# Altium schematic sheet PCIE_CONN.SchDoc(PCIE_CONN)
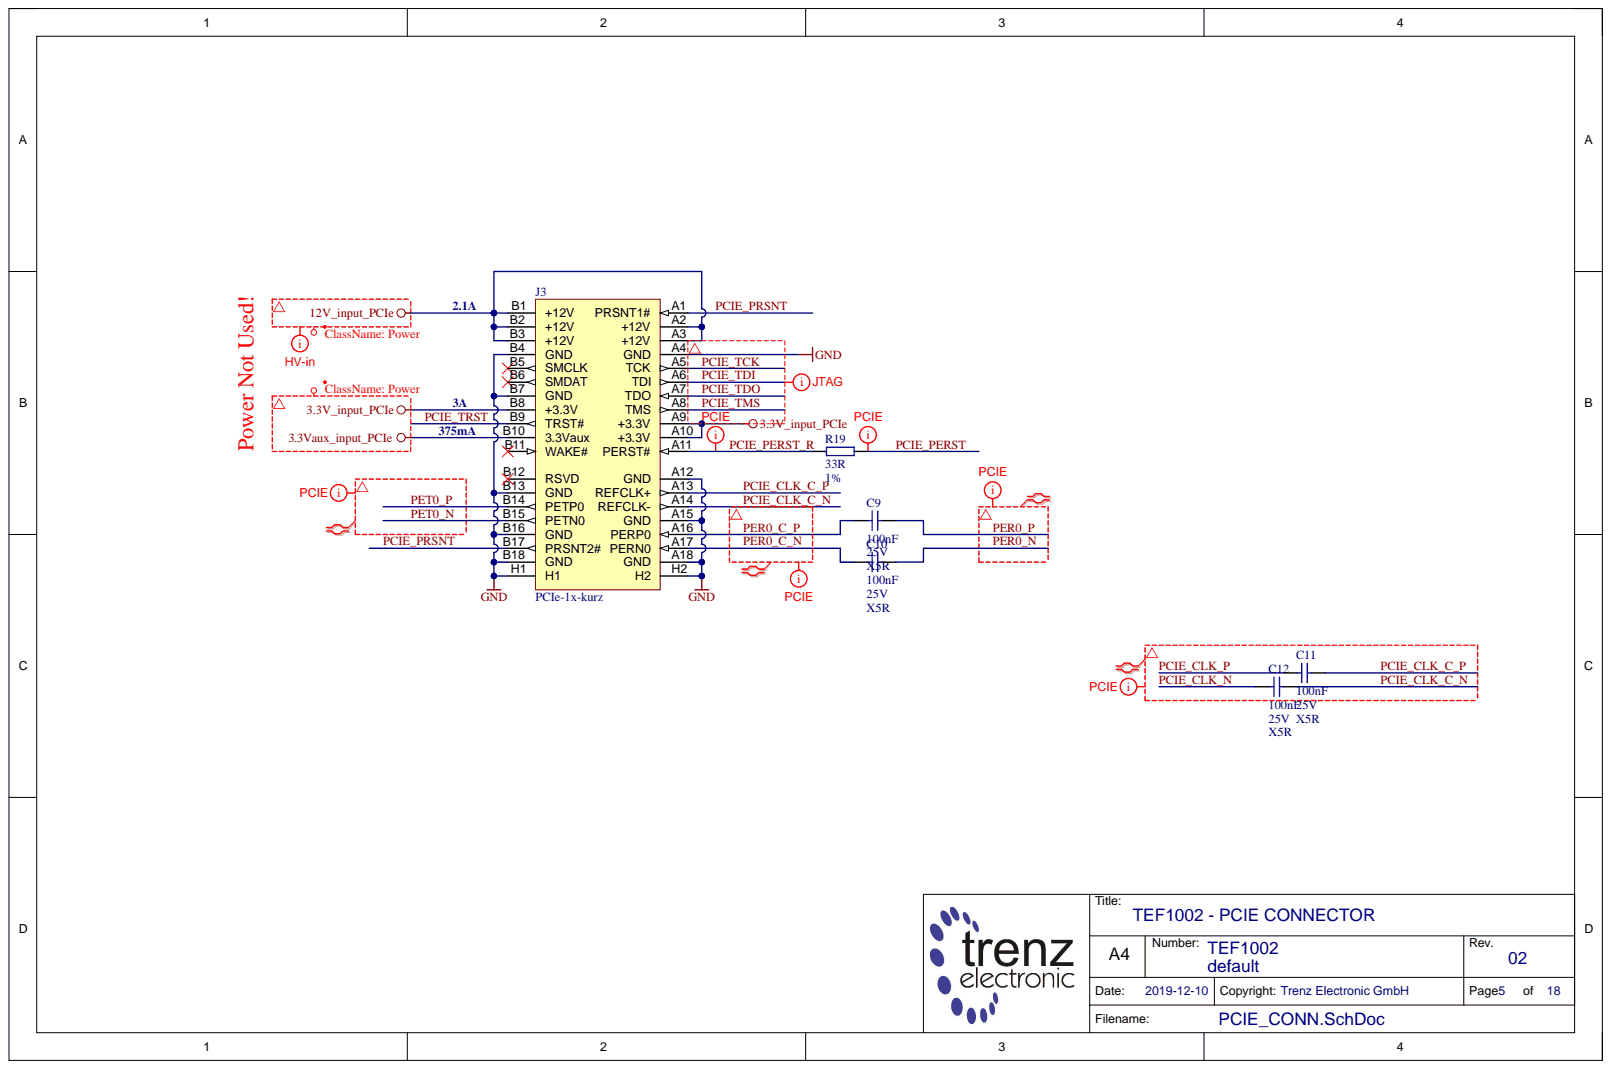
[diagram p0: PCIE_CONN.SchDoc]

## Parts
C9  100nF  [CAPC1005X55N]
C10  100nF  Technology: X5R  Voltage: 25V  [CAPC1005X55N]
C11  100nF  [CAPC1005X55N]
C12  100nF  Technology: X5R  Voltage: 25V  [CAPC1005X55N]
J3  PCIe-1x-kurz  [PCIe-1x-kurz]  (no BOM)
R19  33R  Tolerance: 1%  [RESC0603X26N]

## Nets
3.3V_input_PCIe: J3.A9(+3.3V) J3.A10(+3.3V) J3.B8(+3.3V)
3.3Vaux_input_PCIe: J3.B10(3.3Vaux)
12V_input_PCIe: J3.A2(+12V) J3.A3(+12V) J3.B1(+12V) J3.B2(+12V) J3.B3(+12V)
GND: J3.A4(GND) J3.A12(GND) J3.A15(GND) J3.A18(GND) J3.B4(GND) J3.B7(GND) J3.B13(GND) J3.B16(GND) J3.B18(GND) J3.H1 J3.H2
PCIE_CLK_C_N: C12.2 J3.A14(REFCLK-)
PCIE_CLK_C_P: C11.2 J3.A13(REFCLK+)
PCIE_CLK_N: C12.1
PCIE_CLK_P: C11.1
PCIE_PERST: R19.1
PCIE_PERST_R: J3.A11(PERST#) R19.2
PCIE_PRSNT: J3.A1(PRSNT1#) J3.B17(PRSNT2#)
PCIE_TCK: J3.A5(TCK)
PCIE_TDI: J3.A6(TDI)
PCIE_TDO: J3.A7(TDO)
PCIE_TMS: J3.A8(TMS)
PCIE_TRST: J3.B9(TRST#)
PER0_C_N: C10.2 J3.A17(PERN0)
PER0_C_P: C9.2 J3.A16(PERP0)
PER0_N: C10.1
PER0_P: C9.1
PET0_N: J3.B15(PETN0)
PET0_P: J3.B14(PETP0)

Not connected: J3 B5(SMCLK) B6(SMDAT) B11(WAKE#) B12(RSVD)

## Library data of the parts
C9-C12 = 22472: KON 100nF 25V X5R ±10% SMD BF 1005 (0402); Database Table Name: C_1005_0402; Mixed: SMT; Preferred: Yes; Temperature-Range: -55/+85; Value: 100n; AN: 22472; Case: 1005 (0402); Component Kind: Standard; Library Name: TE.DbLib
  C9 C11: Technology: X5R; Voltage: 25V
J3 = 24548: PCIe-x1 Leiterplatte (kurz); Database Table Name: PCB; AN: 24548; Case: 1 Lane; Library Name: TE.DbLib; HelpURL: B:\AD\adlib\datasheet\PCIexpress
R19 = 25021: WID SMD 33R 1% 0,05W  BF 0603 (0201); Database Table Name: R_0603_0201; H-ART: RC0201FR-0733RL; Mixed: SMT; Preferred: Yes; Value: 33; AN: 25021; Case: 0603 (0201); Component Kind: Standard; Library Name: TE.DbLib; Technology: TK100; HelpURL: B:\AD\adlib\datasheet\R0201
